# Altium schematic sheet CSI.SchDoc(CSI)
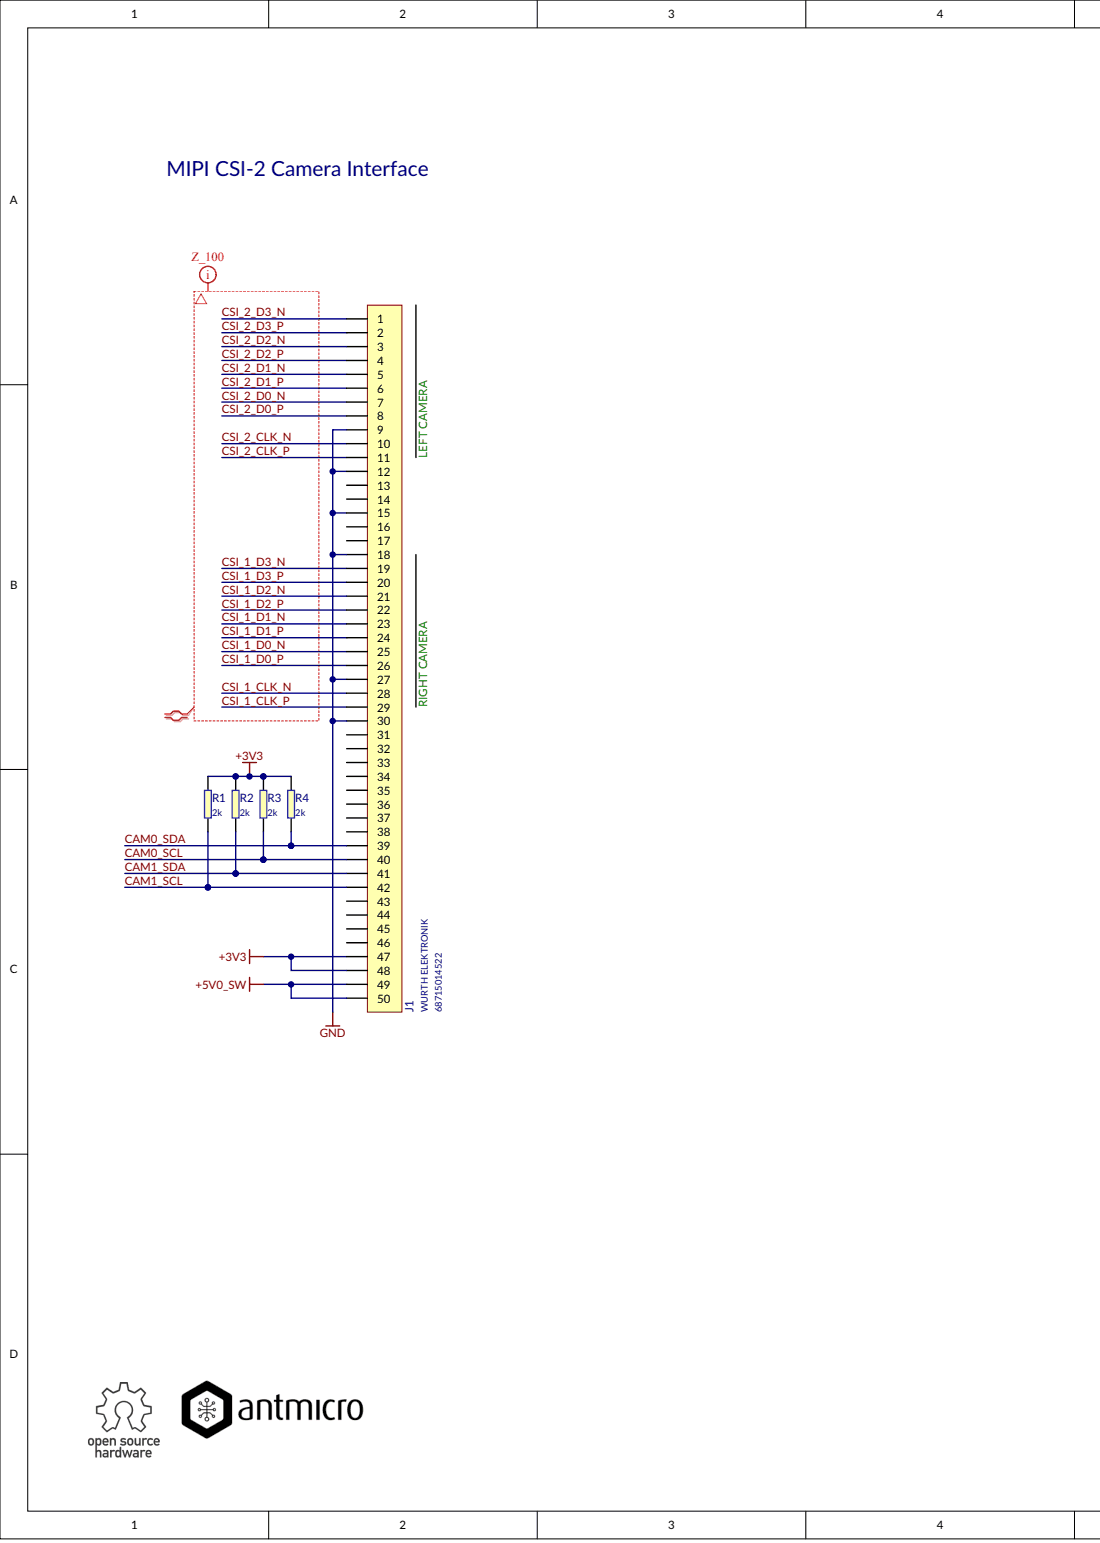
[diagram p0: CSI.SchDoc, left]
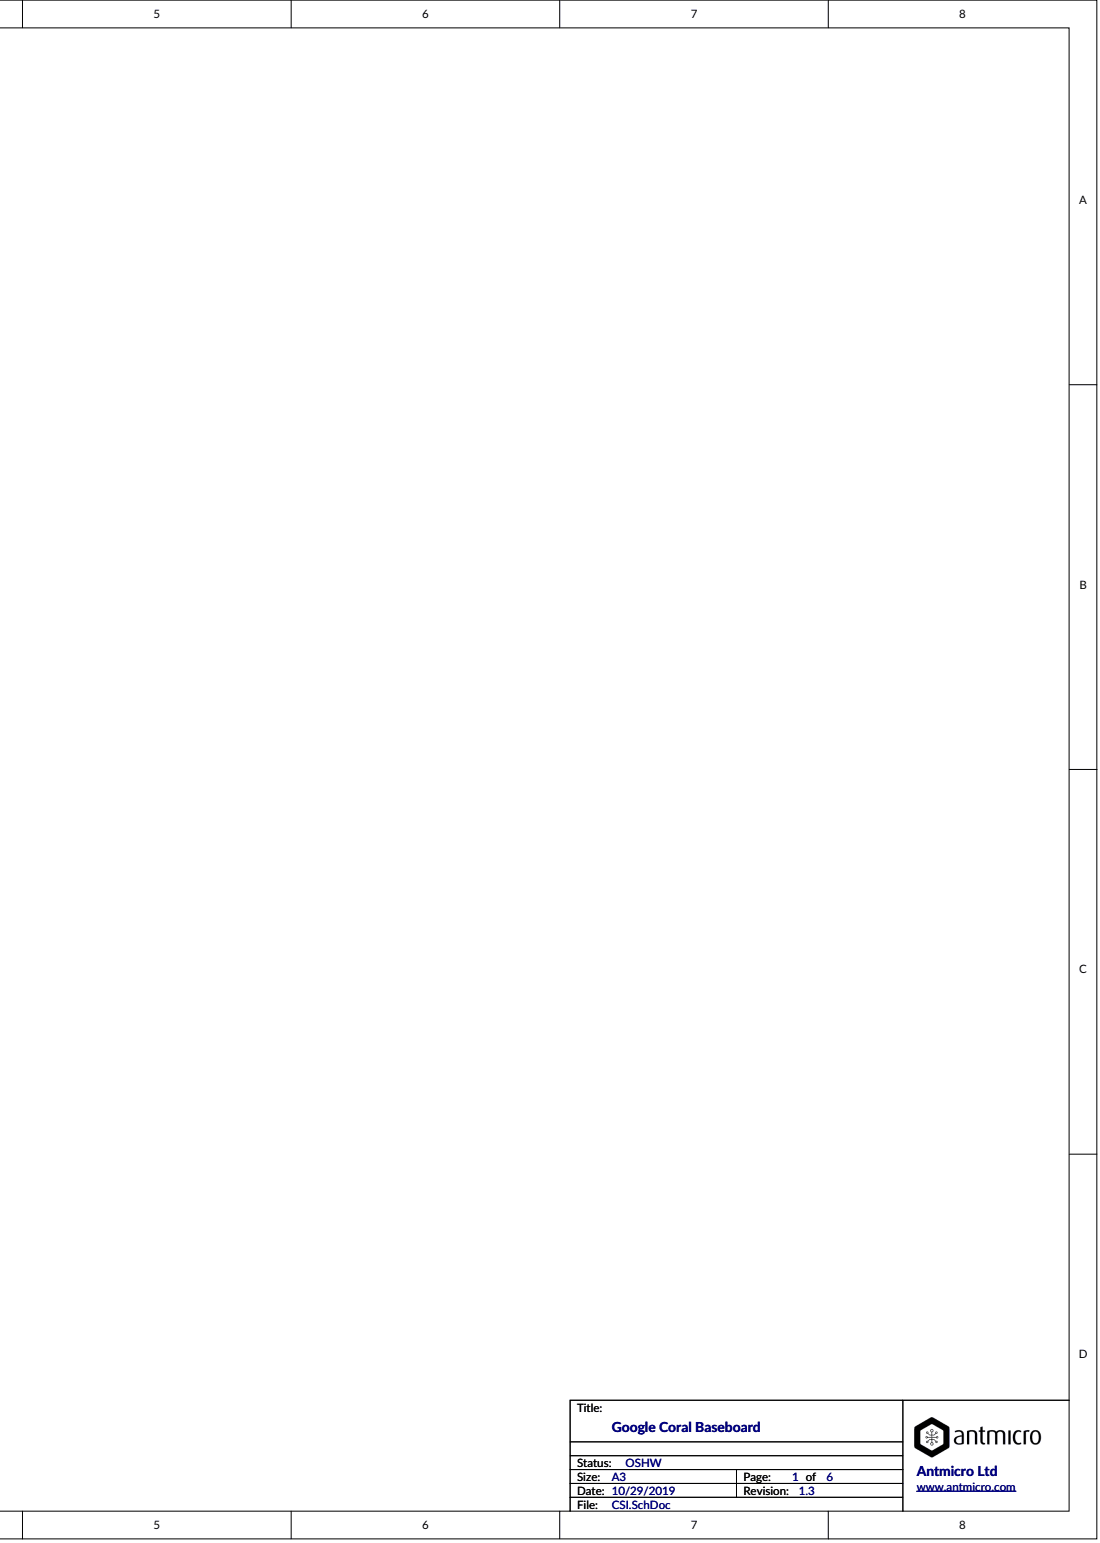
[diagram p1: CSI.SchDoc, right]

## Parts
J1  Manufacturer: WURTH ELEKTRONIK  (hidden comment: 68715014522)
R1  2k
R2  2k
R3  2k
R4  2k

## Nets
+3V3: J1.47 J1.48 R1.1 R2.1 R3.1 R4.1
+5V0_SW: J1.49 J1.50
GND: J1.9 J1.12 J1.15 J1.18 J1.27 J1.30
CAM0_SCL: J1.40 R3.2
CAM0_SDA: J1.39 R4.2
CAM1_SCL: J1.42 R1.2
CAM1_SDA: J1.41 R2.2
CSI_1_CLK_N: J1.28
CSI_1_CLK_P: J1.29
CSI_1_D0_N: J1.25
CSI_1_D0_P: J1.26
CSI_1_D1_N: J1.23
CSI_1_D1_P: J1.24
CSI_1_D2_N: J1.21
CSI_1_D2_P: J1.22
CSI_1_D3_N: J1.19
CSI_1_D3_P: J1.20
CSI_2_CLK_N: J1.10
CSI_2_CLK_P: J1.11
CSI_2_D0_N: J1.7
CSI_2_D0_P: J1.8
CSI_2_D1_N: J1.5
CSI_2_D1_P: J1.6
CSI_2_D2_N: J1.3
CSI_2_D2_P: J1.4
CSI_2_D3_N: J1.1
CSI_2_D3_P: J1.2
N00044:
N00045:

Not connected: J1 13 14 16 17 31 32 33 34 35 36 37 38 43 44 45 46

## Library data of the parts
R1-R4 = (no symbol name): PartNumber: R_2k_0402; Value: 2k
J1 = (no symbol name): Value: 68715014522; PartNumber: 68715014522
